# Altium schematic sheet Hydras_Top.SchDoc
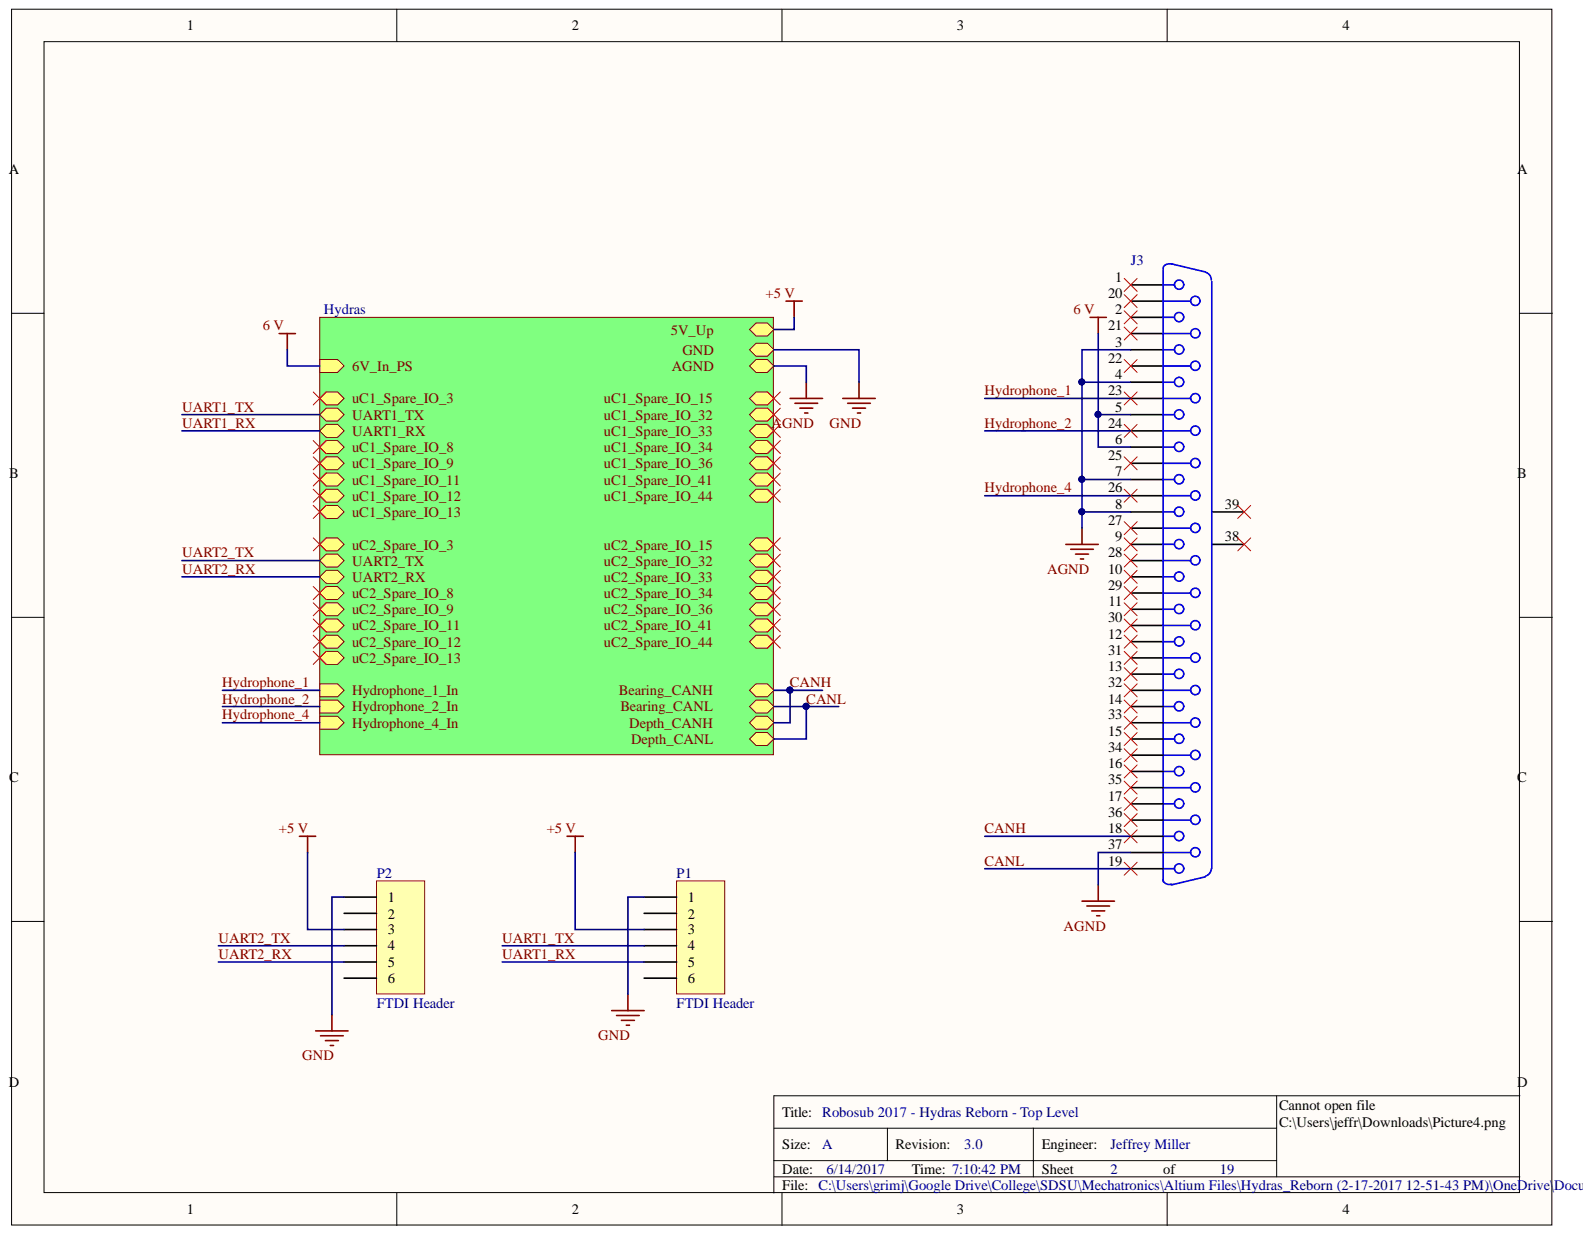
[diagram p0: Hydras_Top.SchDoc]

## Parts
J3  D-SUB 37-Pin  [DSUB37RightAngle]  (value not drawn)
P1  FTDI Header  [HDR1X6]
P2  FTDI Header  [HDR1X6]

## Nets
6 V: J3.5 J3.6
+5 V: P1.3 P2.3
AGND: J3.3 J3.4 J3.7 J3.8 J3.37
GND: P1.1 P2.1
CANH: J3.18
CANL: J3.19
HYDROPHONE_1: J3.23
HYDROPHONE_2: J3.24
HYDROPHONE_4: J3.26
UART1_RX: P1.5
UART1_TX: P1.4
UART2_RX: P2.5
UART2_TX: P2.4
NetJ3_1: J3.1
NetJ3_2: J3.2
NetJ3_9: J3.9
NetJ3_10: J3.10
NetJ3_11: J3.11
NetJ3_12: J3.12
NetJ3_13: J3.13
NetJ3_14: J3.14
NetJ3_15: J3.15
NetJ3_16: J3.16
NetJ3_17: J3.17
NetJ3_20: J3.20
NetJ3_21: J3.21
NetJ3_22: J3.22
NetJ3_25: J3.25
NetJ3_27: J3.27
NetJ3_28: J3.28
NetJ3_29: J3.29
NetJ3_30: J3.30
NetJ3_31: J3.31
NetJ3_32: J3.32
NetJ3_33: J3.33
NetJ3_34: J3.34
NetJ3_35: J3.35
NetJ3_36: J3.36
NetJ3_38: J3.38
NetJ3_39: J3.39
NetP1_2: P1.2
NetP1_6: P1.6
NetP2_2: P2.2
NetP2_6: P2.6
UC1_SPARE_IO_3:
UC1_SPARE_IO_8:
UC1_SPARE_IO_9:
UC1_SPARE_IO_11:
UC1_SPARE_IO_12:
UC1_SPARE_IO_13:
UC1_SPARE_IO_15:
UC1_SPARE_IO_32:
UC1_SPARE_IO_33:
UC1_SPARE_IO_34:
UC1_SPARE_IO_36:
UC1_SPARE_IO_41:
UC1_SPARE_IO_44:
UC2_SPARE_IO_3:
UC2_SPARE_IO_8:
UC2_SPARE_IO_9:
UC2_SPARE_IO_11:
UC2_SPARE_IO_12:
UC2_SPARE_IO_13:
UC2_SPARE_IO_15:
UC2_SPARE_IO_32:
UC2_SPARE_IO_33:
UC2_SPARE_IO_34:
UC2_SPARE_IO_36:
UC2_SPARE_IO_41:
UC2_SPARE_IO_44:

## Library data of the parts
J3 = 37-Pin D-Sub: Component Kind: Standard; Description: D-SUB 37-Pin; Library Name: MechatronicsSCH.SchLib
P1 P2 = Header 6: Header, 6-Pin; Component Kind: Standard; LatestRevisionDate: 17-Jul-2002; LatestRevisionNote: Re-released for DXP Platform.; Library Name: Miscellaneous Connectors.IntLib; Signal Integrity: Connector; Publisher: Altium Limited
